# Altium schematic sheet STM32_AVR_demoboard_4.SchDoc(STM32_AVR_demoboard_4)
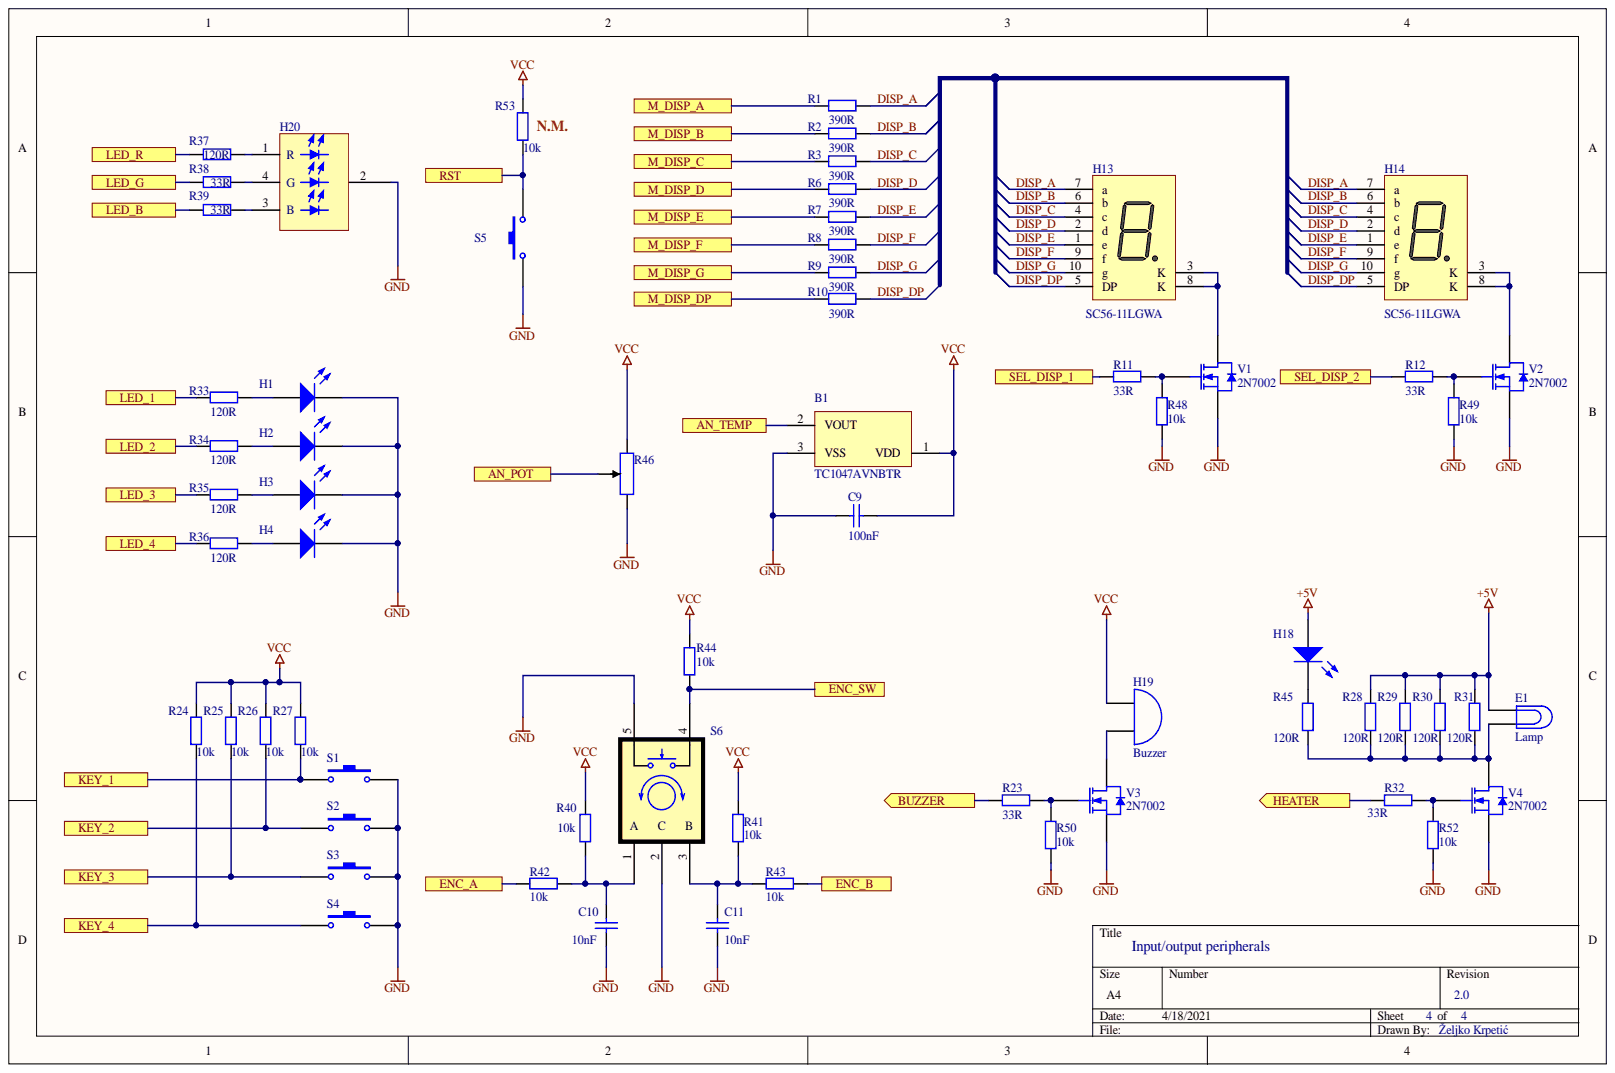
[diagram p0: STM32_AVR_demoboard_4.SchDoc]

## Parts
B1  TC1047AVNBTR  [SOT-23-NB3_N]
C9  100nF  [ERA-0805]
C10  10nF  [ERA-0805]
C11  10nF  [ERA-0805]
E1  Lamp  [PIN2]
H1  LED RED  [LED]  (value not drawn)
H2  LED RED  [LED]  (value not drawn)
H3  LED RED  [LED]  (value not drawn)
H4  LED RED  [LED]  (value not drawn)
H13  SC56-11LGWA  [SC56-11LGWA]
H14  SC56-11LGWA  [SC56-11LGWA]
H18  LED RED  [LED]  (value not drawn)
H19  Buzzer  [ABI-017-RC]
H20  RGB LED  [RGB LED]  (value not drawn)
R1  Resistor  [ERA-0805]  (value not drawn)
R2  value 390R  [ERA-0805]  (hidden comment: Resistor)
R3  value 390R  [ERA-0805]  (hidden comment: Resistor)
R6  value 390R  [ERA-0805]  (hidden comment: Resistor)
R7  value 390R  [ERA-0805]  (hidden comment: Resistor)
R8  value 390R  [ERA-0805]  (hidden comment: Resistor)
R9  value 390R  [ERA-0805]  (hidden comment: Resistor)
R10  value 390R  [ERA-0805]  (hidden comment: Resistor)
R11  value 33R  [ERA-0805]  (hidden comment: Resistor)
R12  value 33R  [ERA-0805]  (hidden comment: Resistor)
R23  value 33R  [ERA-0805]  (hidden comment: Resistor)
R24  value 10k  [ERA-0805]  (hidden comment: Resistor)
R25  value 10k  [ERA-0805]  (hidden comment: Resistor)
R26  value 10k  [ERA-0805]  (hidden comment: Resistor)
R27  value 10k  [ERA-0805]  (hidden comment: Resistor)
R28  value 120R  [8RB-1206]  (hidden comment: Resistor)
R29  value 120R  [8RB-1206]  (hidden comment: Resistor)
R30  value 120R  [8RB-1206]  (hidden comment: Resistor)
R31  value 120R  [8RB-1206]  (hidden comment: Resistor)
R32  value 33R  [ERA-0805]  (hidden comment: Resistor)
R33  value 120R  [ERA-0805]  (hidden comment: Resistor)
R34  value 120R  [ERA-0805]  (hidden comment: Resistor)
R35  value 120R  [ERA-0805]  (hidden comment: Resistor)
R36  value 120R  [ERA-0805]  (hidden comment: Resistor)
R37  value 120R  [ERA-0805]  (hidden comment: Resistor)
R38  value 33R  [ERA-0805]  (hidden comment: Resistor)
R39  value 33R  [ERA-0805]  (hidden comment: Resistor)
R40  value 10k  [ERA-0805]  (hidden comment: Resistor)
R41  value 10k  [ERA-0805]  (hidden comment: Resistor)
R42  value 10k  [ERA-0805]  (hidden comment: Resistor)
R43  value 10k  [ERA-0805]  (hidden comment: Resistor)
R44  value 10k  [ERA-0805]  (hidden comment: Resistor)
R45  120R  [ERA-0805]
R46  Res Tap  (value not drawn)
R48  value 10k  [ERA-0805]  (hidden comment: Resistor)
R49  value 10k  [ERA-0805]  (hidden comment: Resistor)
R50  value 10k  [ERA-0805]  (hidden comment: Resistor)
R52  value 10k  [ERA-0805]  (hidden comment: Resistor)
R53  value 10k  [ERA-0805]  (hidden comment: Resistor)
S1  SW-PB  [6x6mm Tactile switch]  (value not drawn)
S2  SW-PB  [6x6mm Tactile switch]  (value not drawn)
S3  SW-PB  [6x6mm Tactile switch]  (value not drawn)
S4  SW-PB  [6x6mm Tactile switch]  (value not drawn)
S5  SW-PB  [6x6mm Tactile switch]  (value not drawn)
S6  Rotary Encoder  [PEC11R-4xxxF-Sxxxx]  (value not drawn)
V1  2N7002  [SOT23_M]
V2  2N7002  [SOT23_M]
V3  2N7002  [SOT23_M]
V4  2N7002  [SOT23_M]

## Ports
AN_POT, AN_TEMP, BUZZER, ENC_A, ENC_B, ENC_SW, HEATER, KEY_1, KEY_2, KEY_3, KEY_4, LED_1, LED_2, LED_3, LED_4, LED_B, LED_G, LED_R, M_DISP_A, M_DISP_B, M_DISP_C, M_DISP_D, M_DISP_DP, M_DISP_E, M_DISP_F, M_DISP_G, RST, SEL_DISP_1, SEL_DISP_2

## Nets
+5V: E1.1 H18.1 R28.1 R29.1 R30.1 R31.1
GND: B1.3 C9.2 C10.2 C11.2 H1.2 H2.2 H3.2 H4.2 H20.2 R46.1 R48.2 R49.2 R50.2 R52.2 S1.2 S2.2 S3.2 S4.2 S5.1 S6.2 S6.5 V1.2 V2.2 V3.2 V4.2
VCC: B1.1 C9.1 H19.1 R24.1 R25.1 R26.1 R27.1 R40.1 R41.1 R44.1 R46.3 R53.1
AN_POT: R46.2 ; port AN_POT
AN_TEMP: B1.2 ; port AN_TEMP
BUZZER: R23.2 ; port BUZZER
DISP_A: H13.7 H14.7 R1.1
DISP_B: H13.6 H14.6 R2.1
DISP_C: H13.4 H14.4 R3.1
DISP_D: H13.2 H14.2 R6.1
DISP_DP: H13.5 H14.5 R10.1
DISP_E: H13.1 H14.1 R7.1
DISP_F: H13.9 H14.9 R8.1
DISP_G: H13.10 H14.10 R9.1
ENC_A: R42.1 ; port ENC_A
ENC_B: R43.1 ; port ENC_B
ENC_SW: R44.2 S6.4 ; port ENC_SW
HEATER: R32.2 ; port HEATER
KEY_1: R27.2 S1.1 ; port KEY_1
KEY_2: R26.2 S2.1 ; port KEY_2
KEY_3: R25.2 S3.1 ; port KEY_3
KEY_4: R24.2 S4.1 ; port KEY_4
LED_1: R33.2 ; port LED_1
LED_2: R34.2 ; port LED_2
LED_3: R35.2 ; port LED_3
LED_4: R36.2 ; port LED_4
LED_B: R39.2 ; port LED_B
LED_G: R38.2 ; port LED_G
LED_R: R37.2 ; port LED_R
M_DISP_A: R1.2 ; port M_DISP_A
M_DISP_B: R2.2 ; port M_DISP_B
M_DISP_C: R3.2 ; port M_DISP_C
M_DISP_D: R6.2 ; port M_DISP_D
M_DISP_DP: R10.2 ; port M_DISP_DP
M_DISP_E: R7.2 ; port M_DISP_E
M_DISP_F: R8.2 ; port M_DISP_F
M_DISP_G: R9.2 ; port M_DISP_G
RST: R53.2 S5.2 ; port RST
SEL_DISP_1: R11.2 ; port SEL_DISP_1
SEL_DISP_2: R12.2 ; port SEL_DISP_2
NetC10_1: C10.1 R40.2 R42.2 S6.1
NetC11_1: C11.1 R41.2 R43.2 S6.3
NetE1_2: E1.2 R28.2 R29.2 R30.2 R31.2 R45.2 V4.3
NetH1_1: H1.1 R33.1
NetH2_1: H2.1 R34.1
NetH3_1: H3.1 R35.1
NetH4_1: H4.1 R36.1
NetH13_3: H13.3 H13.8 V1.3
NetH14_3: H14.3 H14.8 V2.3
NetH18_2: H18.2 R45.1
NetH19_2: H19.2 V3.3
NetH20_1: H20.1 R37.1
NetH20_3: H20.3 R39.1
NetH20_4: H20.4 R38.1
NetR11_1: R11.1 R48.1 V1.1
NetR12_1: R12.1 R49.1 V2.1
NetR23_1: R23.1 R50.1 V3.1
NetR32_1: R32.1 R52.1 V4.1
N00160:

## Library data of the parts
B1 = TC1047AVNBTR: Precision Temperature-to-Voltage Converter, 3-Pin SOT-23, Extended Temperature, Tape and Reel; PackageReference: SOT-23-NB3; PackageDescription: 3-Lead Plastic Small Outline Transistor (NB) [SOT-23]; Farnell order code: 9762531; DatasheetVersion: revC, May-2005; ComponentLink3URL: http://www.microchip.com/; ComponentLink3Description: Microchip.com Home; ComponentLink2URL: http://www.microchip.com/stellent/groups/techpub_sg/documents/packagingspec/en012702.pdf; ComponentLink2Description: Packaging Specification; ComponentLink1URL: http://ww1.microchip.com/downloads/en/DeviceDoc/21498C.pdf; ComponentLink1Description: Datasheet; Component Kind: Standard; Code_IPC: SOT23-3; Library Name: FER projekt schLib.SchLib
C9-C11 = Cap Semi: Capacitor (Semiconductor SIM Model); Simulation: CAP; Signal Integrity: Cap Semi; Published: 8-Jun-2000; PackageReference: C1206; PackageDescription: Chip Capacitor; Library Name: Miscellaneous Devices.IntLib; LatestRevisionNote: IPC-7351 Footprint Added.; LatestRevisionDate: 29-May-2009; Farnell order code: 2320839; Component Kind: Standard; Publisher: Altium Limited
  C9: Value: 100nF
  C10 C11: Value: 10nF
E1 = Lamp: Incandescent Bulb; Library Name: Miscellaneous Devices.IntLib; Farnell order code: 1139331; Farnell link: https://uk.farnell.com/cml-innovative-technologies/7309/lamp-bi-pin-t1-1-4-6v-0-36w/dp/1139331?MER=bn_search_2TP_LastViewed_1; Component Kind: Standard
H1-H4 H18 = LED: Farnell order code: 8529930; Component Kind: Standard; Library Name: FER projekt schLib.SchLib
H13 H14 = Kingbright SC56-11LGWA: 7 Segment LED Display, Green, 2 mA, 1.9 V, 1.4 mcd, 1, 14.2 mm; Farnell order code: 2217950; Component Kind: Standard; Library Name: FER projekt schLib.SchLib
  H13: Farnell link: https://uk.farnell.com/kingbright/sc56-11lgwa/led-display-0-56-green-com-cath/dp/2217950
H19 = Bell: Electrical Bell; Library Name: Miscellaneous Devices.IntLib; Farnell order code: 1504107; Farnell link: https://uk.farnell.com/pro-signal/abi-017-rc/buzzer-smd-elec-mech-2-4khz/dp/1504107?st=buzzer%20smd; Component Kind: Standard
R1 = Resistor: Value: 390R; Farnell order code: 2447719; Component Kind: Standard; Library Name: FER projekt schLib.SchLib
R45 = Resistor: Value: 120R; Farnell order code: 2073607; Component Kind: Standard; Library Name: FER projekt schLib.SchLib
R46 = Potentiometar: Component Kind: Standard; Library Name: FER projekt schLib rev2.SchLib
S1-S5 = SW-PB: Switch; Published: 24-Mar-1999; PackageReference: SPST-2; PackageDescription: Switch; 2 Leads; Library Name: Miscellaneous Devices.IntLib; LatestRevisionNote: Re-released for DXP Platform.; LatestRevisionDate: 17-Jul-2002; Farnell order code: 9471677; Farnell link: https://uk.farnell.com/multicomp/mcdts6-1k/tactile-switch-4-3mm-100g/dp/9471677; Component Kind: Standard; Publisher: Altium Limited
  S1 S5: Mouser: 506-FSM10JH
V1-V4 = 2N7002: N-channel Enhancement Mode Field-effect Transistor; Simulation: 2N7002; Library Name: Philips Discrete MOSFET - Low Power.IntLib; Farnell order code: 9845313; DatasheetVersion: 27-Jul-2000; ComponentLink2URL: http://www.semiconductors.philips.com/acrobat/datasheets/2N7002_4.pdf; ComponentLink2Description: Datasheet; ComponentLink1URL: http://www.semiconductors.philips.com/; ComponentLink1Description: Manufacturer Link; Component Kind: Standard
H20 = RGB LED: Mouser: 743-HV-5RGB60; Farnell order code: 2290374; Component Kind: Standard; Library Name: FER projekt schLib.SchLib
R2 R3 R6-R12 R23-R44 R48-R50 R52 R53 = Resistor: Component Kind: Standard; Library Name: FER projekt schLib.SchLib
  R2 R3 R6-R10: Farnell order code: 2447719
  R11 R12 R23 R32 R38 R39 R48-R50 R52: Farnell order code: 2447640
  R24-R27 R40-R44 R53: Farnell order code: 2073607
  R28-R31: Farnell order code: 2447462
  R33-R37: Farnell order code: 2447561
S6 = Rotary Encoder: Farnell order code: 2381866; Farnell link: https://uk.farnell.com/bourns/pec11r-4225f-s0024/encoder-incremental-2ch-60rpm/dp/2381866?MER=bn_level5_5NP_EngagementRecSingleItem_1; Component Kind: Standard; Library Name: FER projekt schLib.SchLib
